# Altium schematic sheet 信号调理.SchDoc(信号调理)
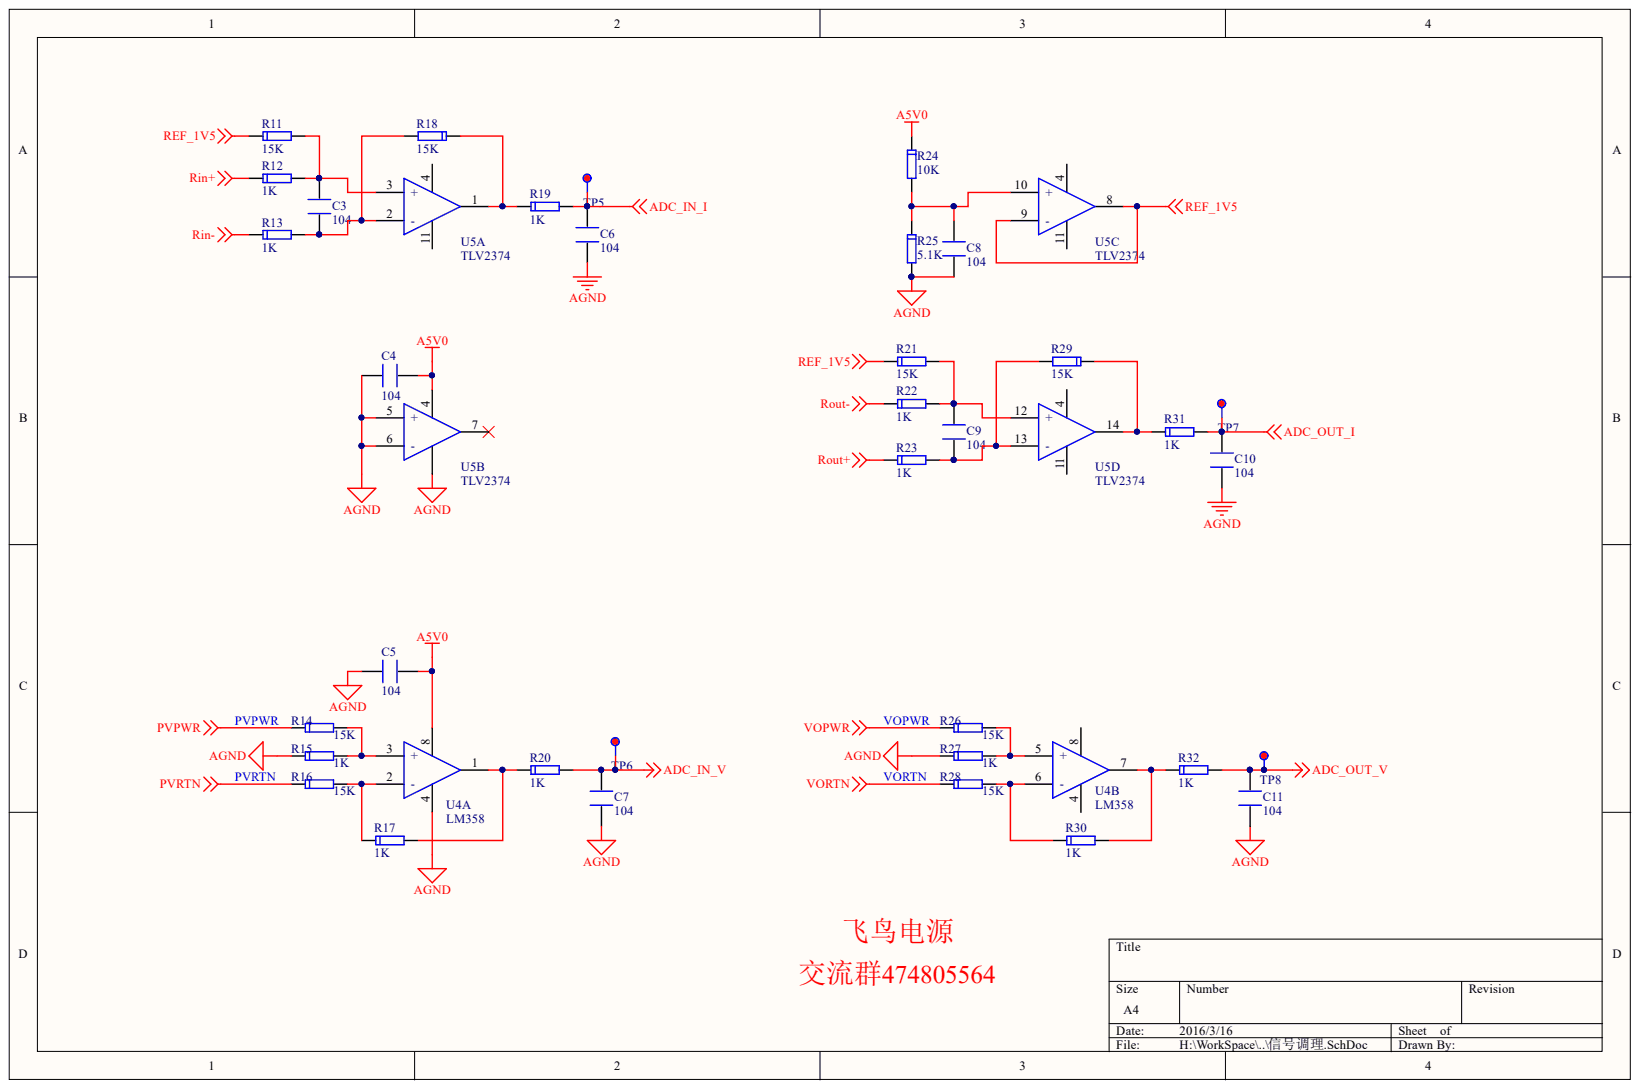
[diagram p0: 信号调理.SchDoc]

## Parts
C3  104  [C0603]
C4  104  [C0603]
C5  104  [C0603]
C6  104  [C0603]
C7  104  [C0603]
C8  104  [C0603]
C9  104  [C0603]
C10  104  [C0603]
C11  104  [C0603]
R11  15K  [R0603]
R12  1K  [R0603]
R13  1K  [R0603]
R14  15K  [R0603]
R15  1K  [R0603]
R16  15K  [R0603]
R17  1K  [R0603]
R18  15K  [R0603]
R19  1K  [R0603]
R20  1K  [R0603]
R21  15K  [R0603]
R22  1K  [R0603]
R23  1K  [R0603]
R24  10K  [R0603]
R25  5.1K  [R0603]
R26  15K  [R0603]
R27  1K  [R0603]
R28  15K  [R0603]
R29  15K  [R0603]
R30  1K  [R0603]
R31  1K  [R0603]
R32  1K  [R0603]
TP5  5005  [Keystone5005]  (value not drawn)
TP6  5005  [Keystone5005]  (value not drawn)
TP7  5005  [Keystone5005]  (value not drawn)
TP8  5005  [Keystone5005]  (value not drawn)
U4  LM358  [SOP8]  (parts A,B)
U5  TLV2374  [SOP14]  (parts A,B,C,D)

## Nets
A5V0: C4.1 C5.1 R24.1 U4.8 U5.4
ADC_IN_I: C6.1 R19.2 TP5.1
ADC_IN_V: C7.1 R20.2 TP6.1
ADC_OUT_I: C10.1 R31.2 TP7.1
ADC_OUT_V: C11.1 R32.2 TP8.1
AGND: C4.2 C5.2 C6.2 C7.2 C8.2 C10.2 C11.2 R15.1 R25.2 R27.1 U4.4 U5.5(+) U5.6(-) U5.11
REF_1V5: R11.1 R21.1 U5.8 U5.9
RIN+: R12.1
RIN-: R13.1
ROUT+: R23.1
ROUT-: R22.1
PVPWR: R14.1
PVRTN: R16.1
VOPWR: R26.1
VORTN: R28.1
NetC3_1: C3.1 R11.2 R12.2 U5.3(+)
NetC3_2: C3.2 R13.2 R18.2 U5.2(-)
NetC8_1: C8.1 R24.2 R25.1 U5.10
NetC9_1: C9.1 R21.2 R22.2 U5.12
NetC9_2: C9.2 R23.2 R29.2 U5.13
NetR14_2: R14.2 R15.2 U4.3(+)
NetR16_2: R16.2 R17.1 U4.2(-)
NetR17_2: R17.2 R20.1 U4.1
NetR18_1: R18.1 R19.1 U5.1
NetR26_2: R26.2 R27.2 U4.5
NetR28_2: R28.2 R30.1 U4.6
NetR29_1: R29.1 R31.1 U5.14
NetR30_2: R30.2 R32.1 U4.7

Not connected: U5 7

## Library data of the parts
C3-C11 = Capacitor: Component Kind: Standard; Library Name: PP_SCHlib_Altium.SchLib
R11-R13 R18 R19 R21-R25 R29 R31 = Resistor: Component Kind: Standard; Library Name: PP_SCH.SchLib
R14-R17 R20 R26-R28 R30 R32 = RESISTOR: Component Kind: Standard; Library Name: PP_SCH.SchLib
TP5-TP8 = 5005: Test Point, Compact, Red, TH; Manufacturer: Keystone; CompType: Test Point Loop; U/M: EA; Component Kind: Standard; ComponentLink1Description: Datasheet Link; ComponentLink1URL: http://www.keyelco.com/userAssets/file/M60-2p60.pdf; LatestRevisionDate: 2013-06-21; Library Name: PP_SCHlib_Altium.SchLib; MountType: TH; PackageReference: Red Compact Testpoint; PartNumber: 5005; RoHS: Y; Supplier 1: Digi-Key; Supplier Part Number 1: 5005K-ND; SymbolVersion: 2008-04-04; Value: Red; Publisher: Texas Instruments
U4 = LM358: Component Kind: Standard; Library Name: PP_SCH.SchLib
U5 = TLV2374: Component Kind: Standard; Library Name: PP_SCH.SchLib
